# Altium schematic sheet LevelConverter.SchDoc(LevelConverter)
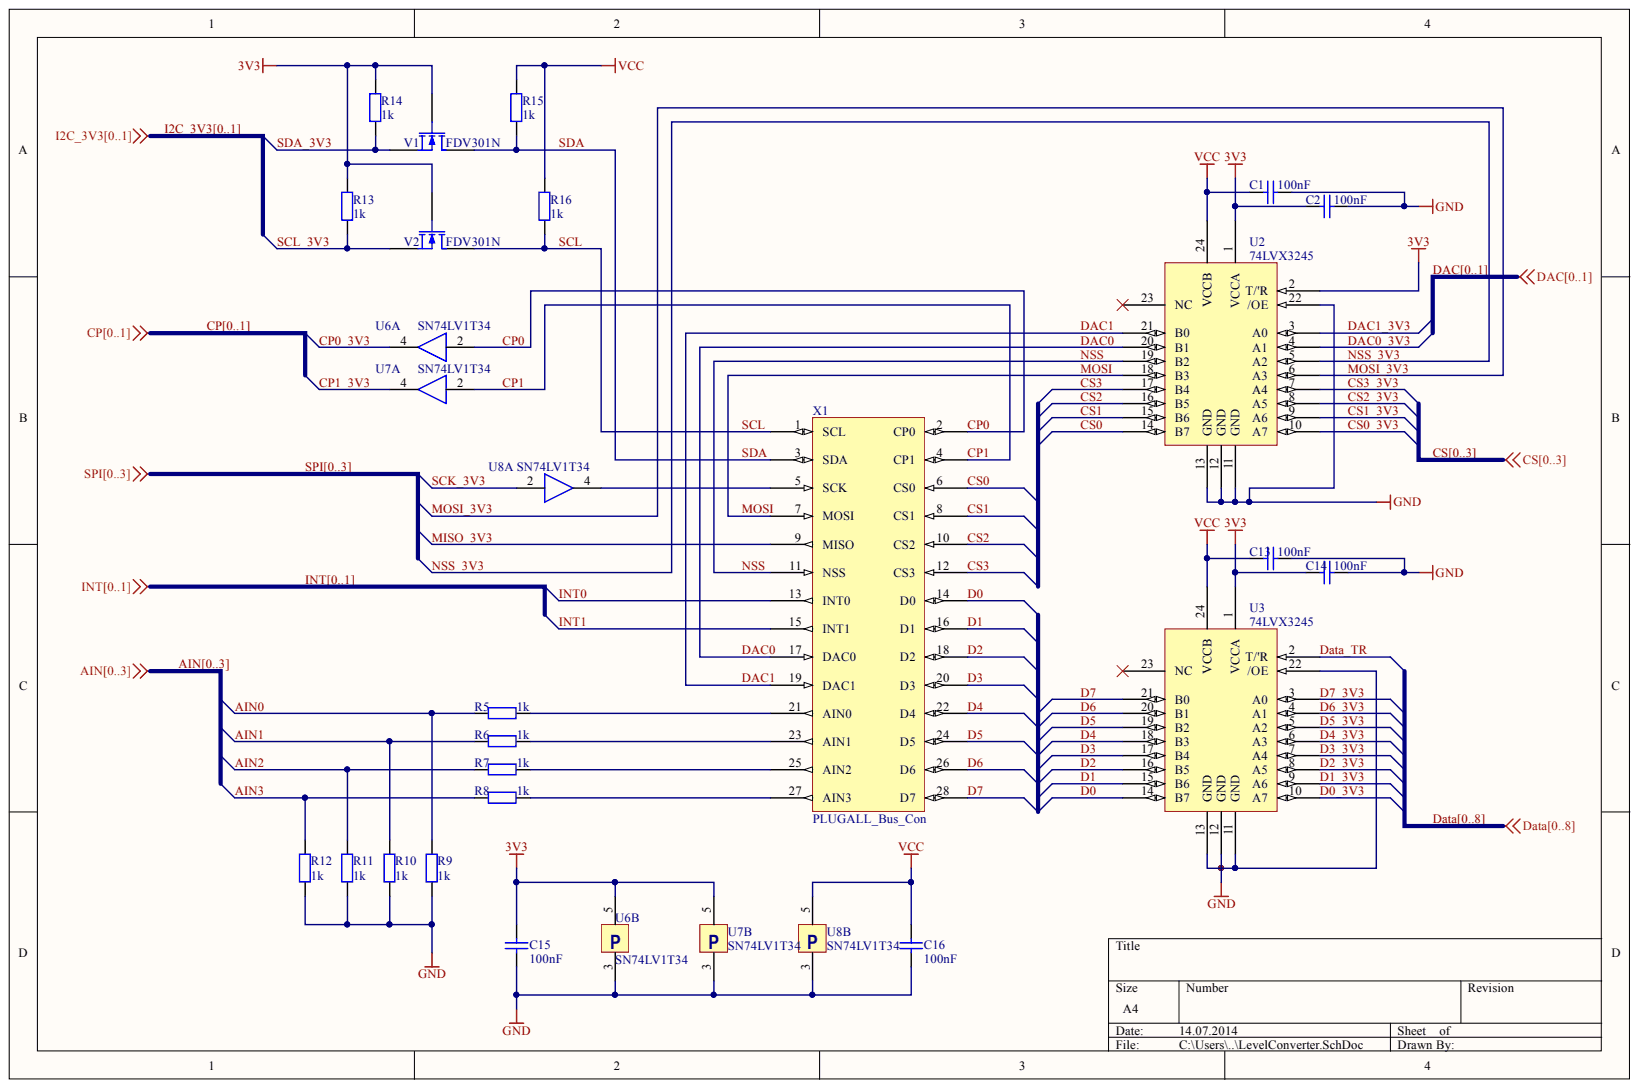
[diagram p0: LevelConverter.SchDoc]

## Parts
C1  C  value 100nF  [0805]
C2  C  value 100nF  [0805]
C13  C  value 100nF  [0805]
C14  C  value 100nF  [0805]
C15  C  value 100nF  [0805]
C16  C  value 100nF  [0805]
R5  R  value 1k  [R_0603]
R6  R  value 1k  [R_0603]
R7  R  value 1k  [R_0603]
R8  R  value 1k  [R_0603]
R9  R  value 1k  [R_0603]
R10  R  value 1k  [R_0603]
R11  R  value 1k  [R_0603]
R12  R  value 1k  [R_0603]
R13  R  value 1k  [R_0603]
R14  R  value 1k  [R_0603]
R15  R  value 1k  [R_0603]
R16  R  value 1k  [R_0603]
U2  74LVX3245  [TSSOP24]
U3  74LVX3245  [TSSOP24]
U6  SN74LV1T34  [DCK]  (parts A,B)
U7  SN74LV1T34  [DCK]  (parts A,B)
U8  SN74LV1T34  [DCK]  (parts A,B)
V1  FDV301N  [SOT-23]
V2  FDV301N  [SOT-23]
X1  PLUGALL_Bus_Con  [CON14X2]

## Nets
3V3: C2.1 C14.1 C15.2 R13.2 R14.2 U2.1(VCCA) U2.2(T/'R) U3.1(VCCA) U6.5 U7.5 V1.2 V2.2
GND: C1.2 C2.2 C13.2 C14.2 C15.1 C16.1 R9.1 R10.1 R11.1 R12.1 U2.11(GND) U2.12(GND) U2.13(GND) U2.22(/OE) U3.11(GND) U3.12(GND) U3.13(GND) U3.22(/OE) U6.3(P) U7.3(P) U8.3(P)
VCC: C1.1 C13.1 C16.2 R15.2 R16.2 U2.24(VCCB) U3.24(VCCB) U8.5
AIN0: R5.1 R9.2  [also labelled AIN]
AIN1: R6.1 R10.2  [also labelled AIN]
AIN2: R7.1 R11.2  [also labelled AIN]
AIN3: R8.1 R12.2  [also labelled AIN]
CP0: U6.2 X1.2(CP0)  [also labelled CP]
CP0_3V3: U6.4
CP1: U7.2 X1.4(CP1)  [also labelled CP]
CP1_3V3: U7.4
CS0: U2.14(B7) X1.6(CS0)  [also labelled CS]
CS0_3V3: U2.10(A7)
CS1: U2.15(B6) X1.8(CS1)  [also labelled CS]
CS1_3V3: U2.9(A6)
CS2: U2.16(B5) X1.10(CS2)  [also labelled CS]
CS2_3V3: U2.8(A5)
CS3: U2.17(B4) X1.12(CS3)  [also labelled CS]
CS3_3V3: U2.7(A4)
D0: U3.14(B7) X1.14(D0)
D0_3V3: U3.10(A7)
D1: U3.15(B6) X1.16(D1)
D1_3V3: U3.9(A6)
D2: U3.16(B5) X1.18(D2)
D2_3V3: U3.8(A5)
D3: U3.17(B4) X1.20(D3)
D3_3V3: U3.7(A4)
D4: U3.18(B3) X1.22(D4)
D4_3V3: U3.6(A3)
D5: U3.19(B2) X1.24(D5)
D5_3V3: U3.5(A2)
D6: U3.20(B1) X1.26(D6)
D6_3V3: U3.4(A1)
D7: U3.21(B0) X1.28(D7)
D7_3V3: U3.3(A0)
DAC0: U2.20(B1) X1.17(DAC0)  [also labelled DAC]
DAC0_3V3: U2.4(A1)
DAC1: U2.21(B0) X1.19(DAC1)  [also labelled DAC]
DAC1_3V3: U2.3(A0)
DATA_TR: U3.2(T/'R)
INT0: X1.13(INT0)  [also labelled INT]
INT1: X1.15(INT1)  [also labelled INT]
MISO_3V3: X1.9(MISO)
MOSI: U2.18(B3) X1.7(MOSI)
MOSI_3V3: U2.6(A3)
NSS: U2.19(B2) X1.11(NSS)
NSS_3V3: U2.5(A2)
SCK_3V3: U8.2
SCL: R16.1 V2.1 X1.1(SCL)
SCL_3V3: R13.1 V2.3
SDA: R15.1 V1.1 X1.3(SDA)
SDA_3V3: R14.1 V1.3
NetR5_2: R5.2 X1.21(AIN0)
NetR6_2: R6.2 X1.23(AIN1)
NetR7_2: R7.2 X1.25(AIN2)
NetR8_2: R8.2 X1.27(AIN3)
NetU8_4: U8.4 X1.5(SCK)
DATA0:  [also labelled DATA]
DATA1:  [also labelled DATA]
DATA2:  [also labelled DATA]
DATA3:  [also labelled DATA]
DATA4:  [also labelled DATA]
DATA5:  [also labelled DATA]
DATA6:  [also labelled DATA]
DATA7:  [also labelled DATA]
DATA8:  [also labelled DATA]
I2C_3V3[0..1]:
I2C_3V30:
I2C_3V31:
N00155:
N00156:
SPI0:  [also labelled SPI]
SPI1:  [also labelled SPI]
SPI2:  [also labelled SPI]
SPI3:  [also labelled SPI]

Not connected: U2 23(NC); U3 23(NC)

## Library data of the parts
R5-R16 = RES_EU: Component Kind: Standard; Library Name: Schlib1.SchLib
V1 V2 = MOSFET-N: Component Kind: Standard; Library Name: Schlib1.SchLib
X1 = PLUGALL_Bus_Con: PLUGALL Card Connector; Component Kind: Standard; Library Name: Schlib1.SchLib
C1 C2 C13-C16 = C: Component Kind: Standard; Library Name: Schlib1.SchLib
U2 U3 = 74LVX3245: Component Kind: Standard; Library Name: Schlib1.SchLib
U6-U8 = SN74LV1T34: Component Kind: Standard; Library Name: Schlib1.SchLib
